# Altium schematic sheet Mechanical.SchDoc(Mechanical)
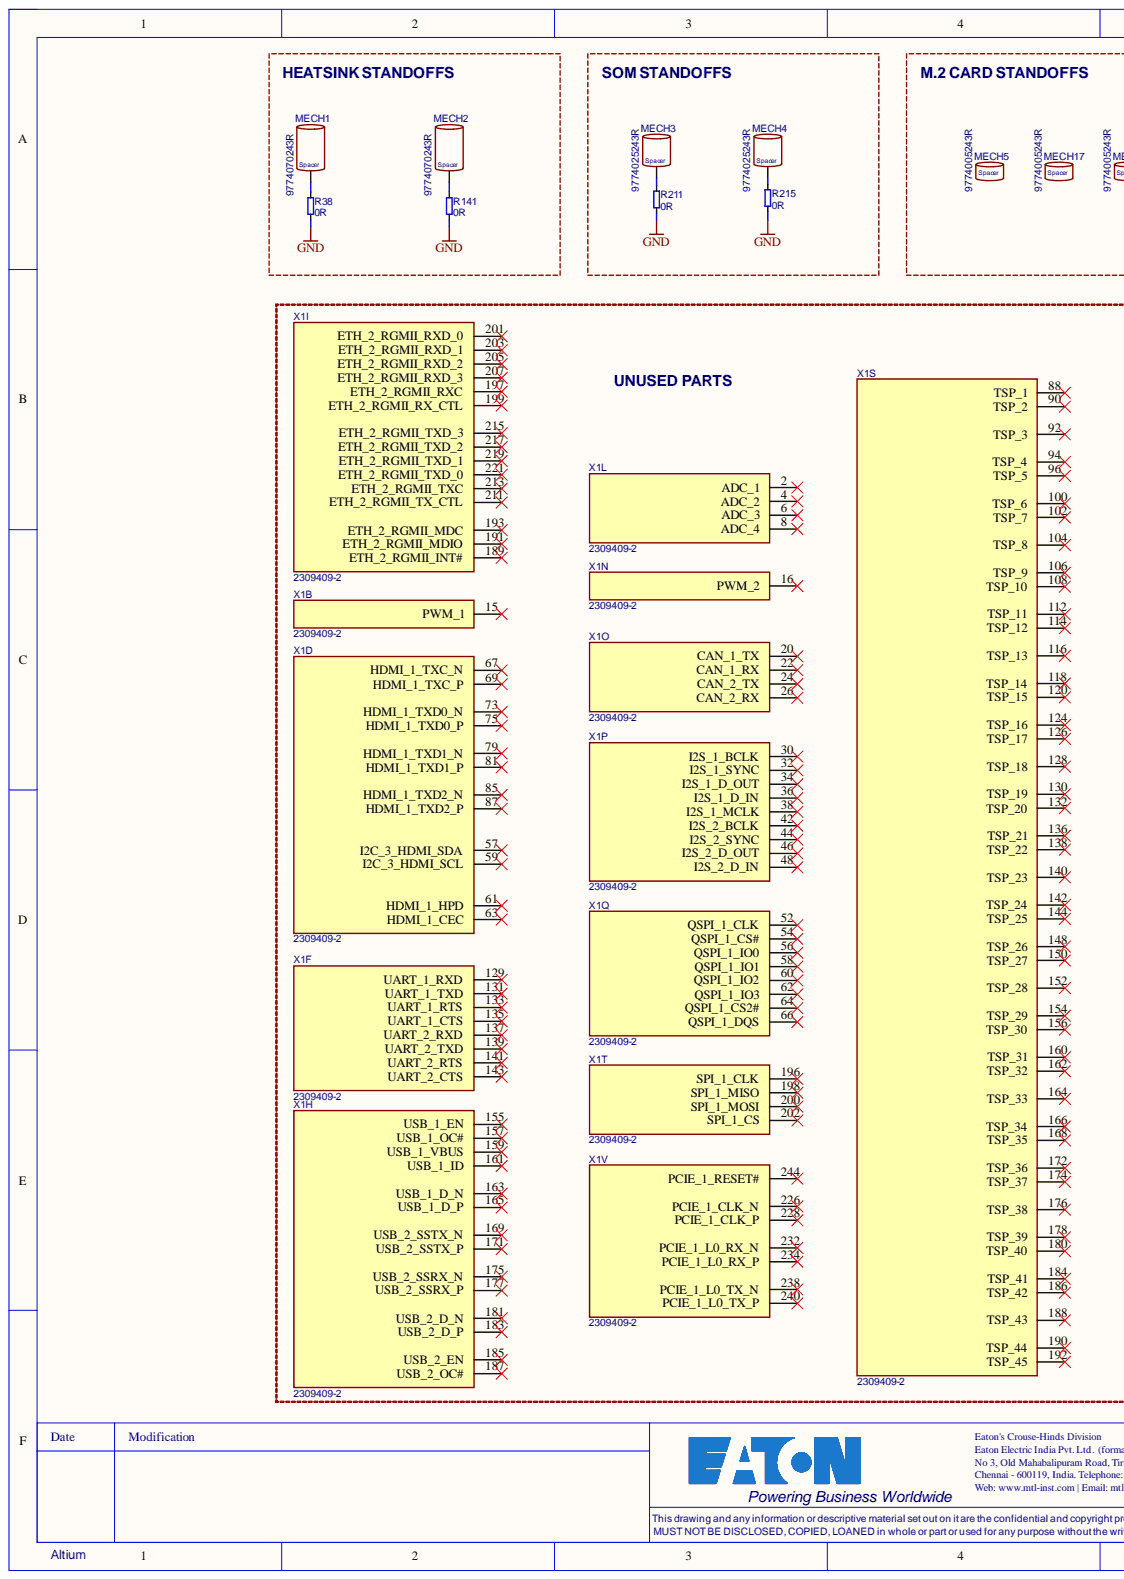
[diagram p0: Mechanical.SchDoc, left]
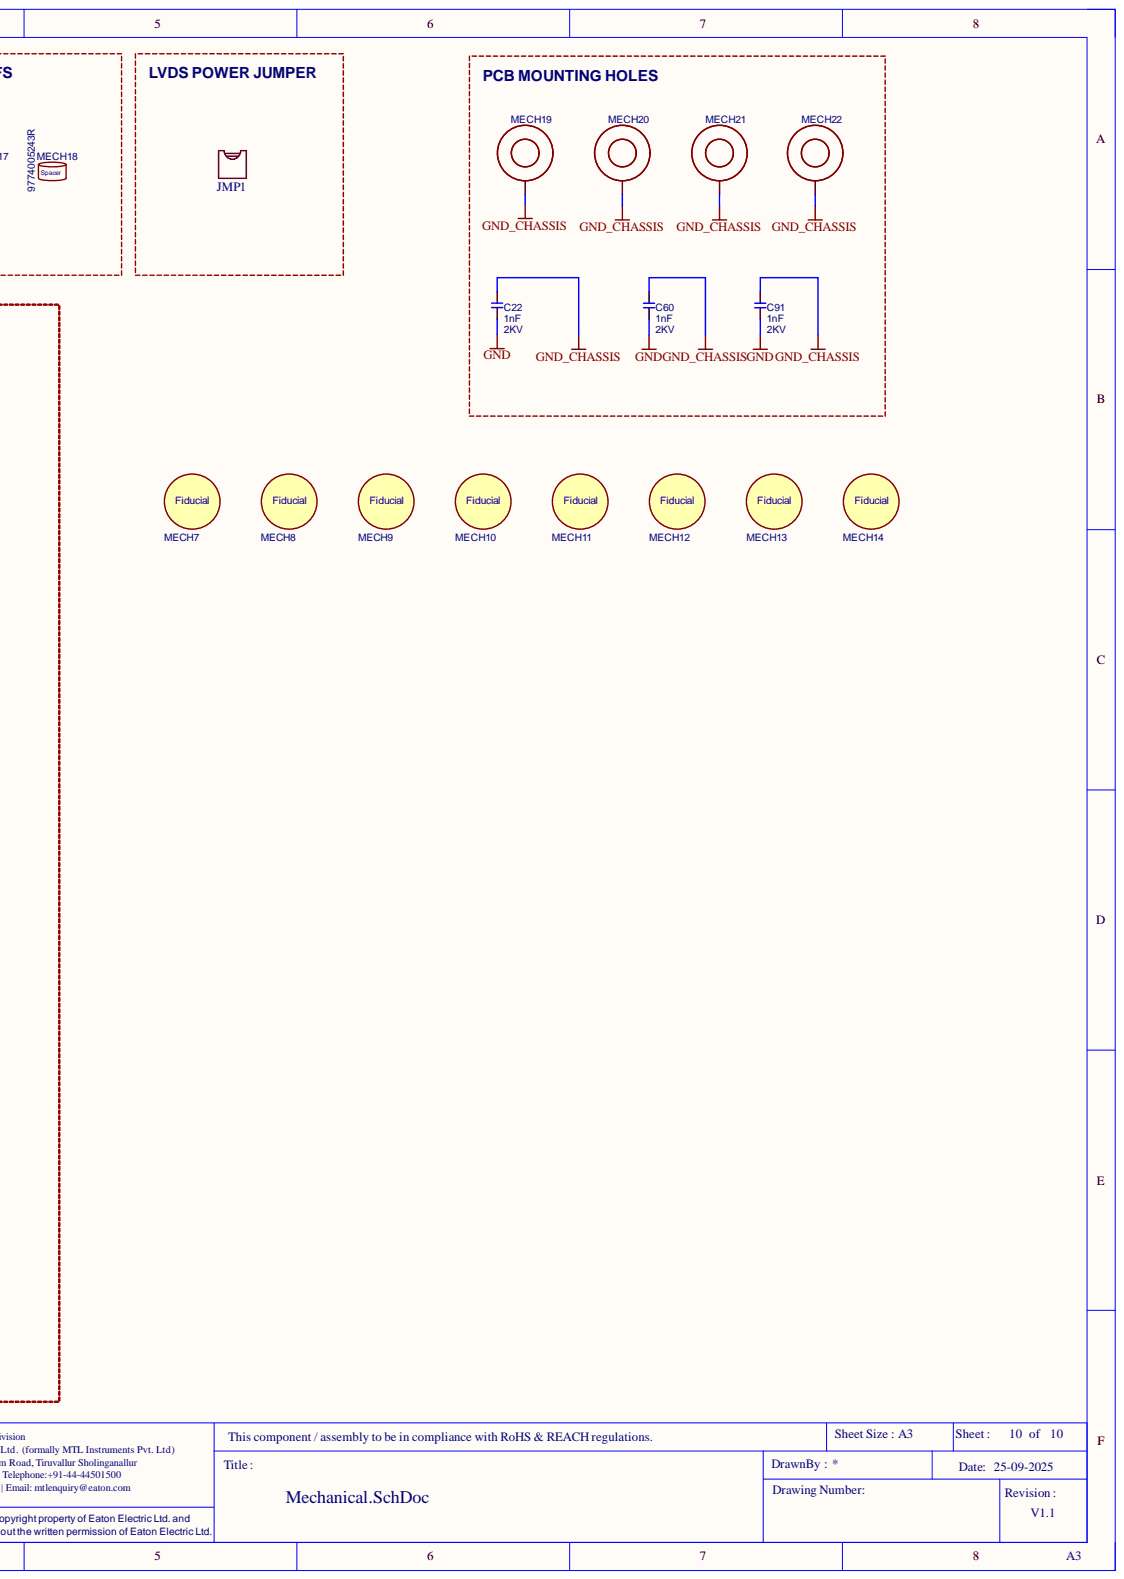
[diagram p1: Mechanical.SchDoc, right]

## Parts
C22  1nF  Rating: 2KV  [T_CAPC1206X180N]
C60  1nF  Rating: 2KV  [T_CAPC1206X180N]
C91  1nF  Rating: 2KV  [T_CAPC1206X180N]
JMP1  Shunt Jumper  (value not drawn)  (mechanical)
MECH1  Manufacturer Part No.: 9774070243R  [MECH-Wurth-9774070243R]  (hidden comment: Wurth-9774070243R)
MECH2  Manufacturer Part No.: 9774070243R  [MECH-Wurth-9774070243R]  (hidden comment: Wurth-9774070243R)
MECH3  Manufacturer Part No.: 9774025243R  [MECH-Wurth-9774025243R]  (hidden comment: Wurth-9774025243R)
MECH4  Manufacturer Part No.: 9774025243R  [MECH-Wurth-9774025243R]  (hidden comment: Wurth-9774025243R)
MECH5  Manufacturer Part No.: 9774005243R  [MECH-WURTH-9774005243R]  (hidden comment: Wurth-9774005243R)
MECH7  MECH-Fiducial  [T_Fiducial]  (value not drawn)  (no BOM)
MECH8  MECH-Fiducial  [T_Fiducial]  (value not drawn)  (no BOM)
MECH9  MECH-Fiducial  [T_Fiducial]  (value not drawn)  (no BOM)
MECH10  MECH-Fiducial  [T_Fiducial]  (value not drawn)  (no BOM)
MECH11  MECH-Fiducial  [T_Fiducial]  (value not drawn)  (no BOM)
MECH12  MECH-Fiducial  [T_Fiducial]  (value not drawn)  (no BOM)
MECH13  MECH-Fiducial  [T_Fiducial]  (value not drawn)  (no BOM)
MECH14  MECH-Fiducial  [T_Fiducial]  (value not drawn)  (no BOM)
MECH17  Manufacturer Part No.: 9774005243R  [MECH-WURTH-9774005243R]  (hidden comment: Wurth-9774005243R)
MECH18  Manufacturer Part No.: 9774005243R  [MECH-WURTH-9774005243R]  (hidden comment: Wurth-9774005243R)
MECH19  MECH-MOUNTING-HOLE  [MECH-MONTING-HOLE]  (value not drawn)  (no BOM)
MECH20  MECH-MOUNTING-HOLE  [MECH-MONTING-HOLE]  (value not drawn)  (no BOM)
MECH21  MECH-MOUNTING-HOLE  [MECH-MONTING-HOLE]  (value not drawn)  (no BOM)
MECH22  MECH-MOUNTING-HOLE  [MECH-MONTING-HOLE]  (value not drawn)  (no BOM)
R38  0R  [RESC1005X40N]
R141  0R  [RESC1005X40N]
R211  0R  [RESC1005X40N]
R215  0R  [RESC1005X40N]
X1  2309409-2  [CON-TE-2309409-2]  (parts B,D,F,H,I,L,N,O,P,Q,S,T,V)

## Nets
GND: C22.1 C60.1 C91.1 R38.1 R141.1 R211.1 R215.1
GND_CHASSIS: C22.2 C60.2 C91.2 MECH19.0 MECH20.0 MECH21.0 MECH22.0
NetMECH1_1: MECH1.1 R38.2
NetMECH2_1: MECH2.1 R141.2
NetMECH3_1: MECH3.1 R211.2
NetMECH4_1: MECH4.1 R215.2

Not connected: X1 2(ADC_1) 4(ADC_2) 6(ADC_3) 8(ADC_4) 15(PWM_1) 16(PWM_2) 20(CAN_1_TX) 22(CAN_1_RX) 24(CAN_2_TX) 26(CAN_2_RX) 30(I2S_1_BCLK) 32(I2S_1_SYNC) 34(I2S_1_D_OUT) 36(I2S_1_D_IN) 38(I2S_1_MCLK) 42(I2S_2_BCLK) 44(I2S_2_SYNC) 46(I2S_2_D_OUT) 48(I2S_2_D_IN) 52(QSPI_1_CLK) 54(QSPI_1_CS#) 56(QSPI_1_IO0) 57(I2C_3_HDMI_SDA) 58(QSPI_1_IO1) 59(I2C_3_HDMI_SCL) 60(QSPI_1_IO2) 61(HDMI_1_HPD) 62(QSPI_1_IO3) 63(HDMI_1_CEC) 64(QSPI_1_CS2#) 66(QSPI_1_DQS) 67(HDMI_1_TXC_N) 69(HDMI_1_TXC_P) 73(HDMI_1_TXD0_N) 75(HDMI_1_TXD0_P) 79(HDMI_1_TXD1_N) 81(HDMI_1_TXD1_P) 85(HDMI_1_TXD2_N) 87(HDMI_1_TXD2_P) 88(TSP_1) 90(TSP_2) 92(TSP_3) 94(TSP_4) 96(TSP_5) 100(TSP_6) 102(TSP_7) 104(TSP_8) 106(TSP_9) 108(TSP_10) 112(TSP_11) 114(TSP_12) 116(TSP_13) 118(TSP_14) 120(TSP_15) 124(TSP_16) 126(TSP_17) 128(TSP_18) 129(UART_1_RXD) 130(TSP_19) 131(UART_1_TXD) 132(TSP_20) 133(UART_1_RTS) 135(UART_1_CTS) 136(TSP_21) 137(UART_2_RXD) 138(TSP_22) 139(UART_2_TXD) 140(TSP_23) 141(UART_2_RTS) 142(TSP_24) 143(UART_2_CTS) 144(TSP_25) 148(TSP_26) 150(TSP_27) 152(TSP_28) 154(TSP_29) 155(USB_1_EN) 156(TSP_30) 157(USB_1_OC#) 159(USB_1_VBUS) 160(TSP_31) 161(USB_1_ID) 162(TSP_32) 163(USB_1_D_N) 164(TSP_33) 165(USB_1_D_P) 166(TSP_34) 168(TSP_35) 169(USB_2_SSTX_N) 171(USB_2_SSTX_P) 172(TSP_36) 174(TSP_37) 175(USB_2_SSRX_N) 176(TSP_38) 177(USB_2_SSRX_P) 178(TSP_39) 180(TSP_40) 181(USB_2_D_N) 183(USB_2_D_P) 184(TSP_41) 185(USB_2_EN) 186(TSP_42) 187(USB_2_OC#) 188(TSP_43) 189(ETH_2_RGMII_INT#) 190(TSP_44) 191(ETH_2_RGMII_MDIO) 192(TSP_45) 193(ETH_2_RGMII_MDC) 196(SPI_1_CLK) 197(ETH_2_RGMII_RXC) 198(SPI_1_MISO) 199(ETH_2_RGMII_RX_CTL) 200(SPI_1_MOSI) 201(ETH_2_RGMII_RXD_0) 202(SPI_1_CS) 203(ETH_2_RGMII_RXD_1) 205(ETH_2_RGMII_RXD_2) 207(ETH_2_RGMII_RXD_3) 211(ETH_2_RGMII_TX_CTL) 213(ETH_2_RGMII_TXC) 215(ETH_2_RGMII_TXD_3) 217(ETH_2_RGMII_TXD_2) 219(ETH_2_RGMII_TXD_1) 221(ETH_2_RGMII_TXD_0) 226(PCIE_1_CLK_N) 228(PCIE_1_CLK_P) 232(PCIE_1_L0_RX_N) 234(PCIE_1_L0_RX_P) 238(PCIE_1_L0_TX_N) 240(PCIE_1_L0_TX_P) 244(PCIE_1_RESET#)

## Library data of the parts
C22 C60 C91 = C-X7R-1nF-2KV-10%-1206: Capacitor Ceramic X7R 1nF 2KV 10% 1206; Manufacturer Name: KEMET; Manufacturer Part No.: C1206C102KGRACTM; Component Kind: Standard; Library Name: SoM_Camera_Encoder.SCHLIB; Tolerance: 10%; Type: X7R; Value: 1nF
JMP1 = MECH-Shunt-Jumper-Black-2.54mm: Manufacturer Name: Assmann; Manufacturer Part No.: AKSPT/G-BLACK; Description: Shunt Jumper; Library Name: Mallow.SchLib
MECH1 MECH2 = MECH-Wurth-9774070243R: Round Standoff M2x0.4 (7.00mm); Manufacturer Name: Wurth; Component Kind: Standard; Library Name: Mallow.SchLib
MECH3 MECH4 = MECH-Wurth-9774025243R: Round Standoff M2x0.4 (2.50mm); Manufacturer Name: Wurth; Component Kind: Standard; Library Name: Mallow.SchLib
MECH5 MECH17 MECH18 = MECH-Wurth-9774005243R: Round Standoff M2x0.4 (0.50mm); Manufacturer Name: Wurth; Component Kind: Standard; Library Name: Mallow.SchLib
MECH7-MECH14 = MECH-Fiducial: Fiducial; Library Name: Mallow.SchLib
MECH19-MECH22 = MECH-MOUNTING-HOLE: Mounting hole 3.2mm; Library Name: Mallow.SchLib
R38 R141 R211 R215 = R-0R-63mW-1%-0402: Resistor 0 ohm 63mW 1% 0402; Manufacturer Name: Thin Film Technology Corp.; Manufacturer Part No.: TFAS0402WJUMPF-T10; Component Kind: Standard; Library Name: SoM_Camera_Encoder.SCHLIB; Rating: 63mW; Tolerance: 1%; Value: 0R
X1 = CON-TE-2309409-2: DDR4 SODIMM Socket, 260 pins, 5.2mm(H), 0.5mm Pitch; Manufacturer Name: TE Connectivity; Component Kind: Standard; Library Name: SoM_Camera_Encoder.SCHLIB; Manufacturer Part No.: 2309409-2; Package: DDR4-SODIMM-260; Temperature Range: -40C to +125C
